# Altium schematic sheet 02-ALIM_2.SchDoc(02-ALIM_2)
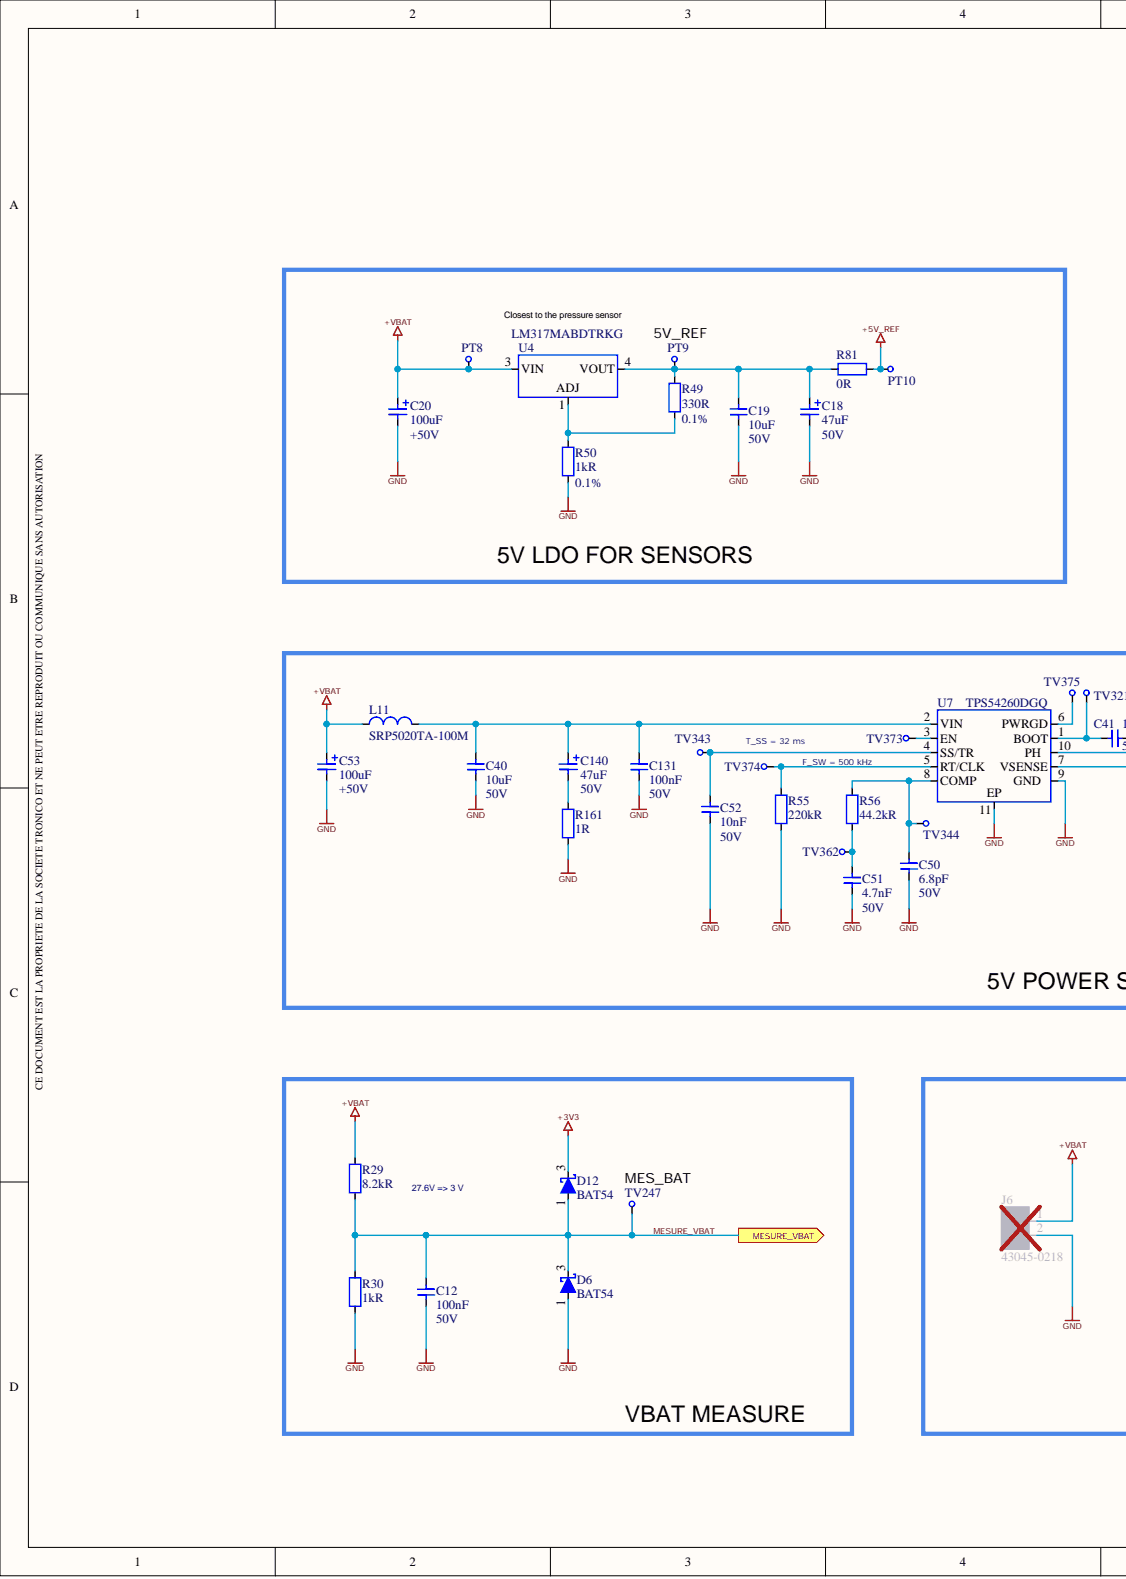
[diagram p0: 02-ALIM_2.SchDoc, left]
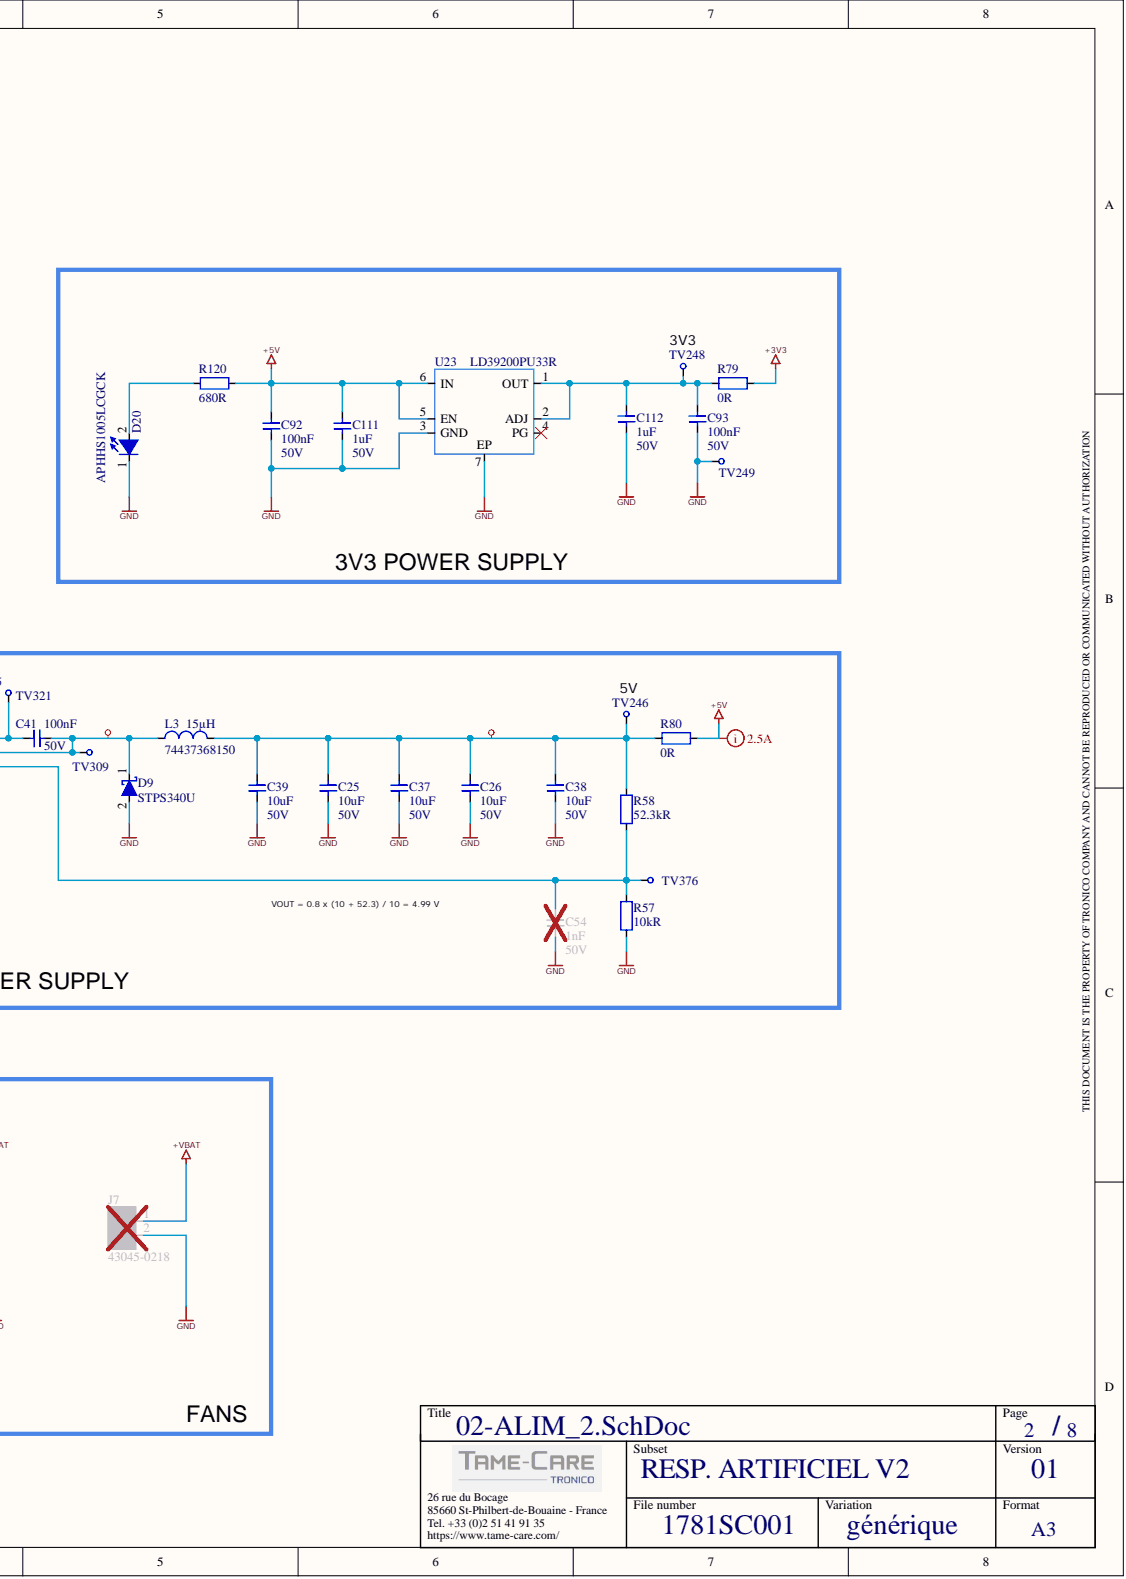
[diagram p1: 02-ALIM_2.SchDoc, right]

## Parts
C12  100nF  Parameter 1: 50V  [CAPC_0603X094_T]
C18  47uF  Parameter 1: 50V  [CAPE_D_B]
C19  10uF  Parameter 1: 50V  [CAPC_1206X160_T]
C20  100uF  Parameter 1: +50V  [CAPE_G_B]
C25  10uF  Parameter 1: 50V  [CAPC_1206X160_T]
C26  10uF  Parameter 1: 50V  [CAPC_1206X160_T]
C37  10uF  Parameter 1: 50V  [CAPC_1206X160_T]
C38  10uF  Parameter 1: 50V  [CAPC_1206X160_T]
C39  10uF  Parameter 1: 50V  [CAPC_1206X160_T]
C40  10uF  Parameter 1: 50V  [CAPC_1206X160_T]
C41  100nF  Parameter 1: 50V  [CAPC_0603X094_T]
C50  6.8pF  Parameter 1: 50V  [CAPC_0603X094_T]
C51  4.7nF  Parameter 1: 50V  [CAPC_0603X080_T]
C52  10nF  Parameter 1: 50V  [CAPC_0603X080_T]
C53  100uF  Parameter 1: +50V  [CAPE_G_B]
C54  1nF  Parameter 1: 50V  [CAPC_0603X080_T]
C92  100nF  Parameter 1: 50V  [CAPC_0603X094_T]
C93  100nF  Parameter 1: 50V  [CAPC_0603X094_T]
C111  1uF  Parameter 1: 50V  [CAPC_0603X080_T]
C112  1uF  Parameter 1: 50V  [CAPC_0603X080_T]
C131  100nF  Parameter 1: 50V  [CAPC_0603X094_T]
C140  47uF  Parameter 1: 50V  [CAPE_D_B]
D6  BAT54  [SOT23_T]
D9  STPS340U  [DIOC_SMB_DO-214AA_T]
D12  BAT54  [SOT23_T]
D20  APHHS1005LCGCK  [LEDC_0402x050_GREEN_B]
J6  43045-0218  [CNT_MOLEX_43045-0218_B]
J7  43045-0218  [CNT_MOLEX_43045-0218_B]
L3  74437368150  CHAMP5: 15µH  [INDC_WE-1040_B]
L11  SRP5020TA-100M  [INDC_SRP5020T_B]
PT8  PT_0.4  [PT_0.4-1.0mm]  (value not drawn)  (no BOM)
PT9  PT_0.4  [PT_0.4-1.0mm]  (value not drawn)  (no BOM)
PT10  PT_0.4  [PT_0.4-1.0mm]  (value not drawn)  (no BOM)
R29  8.2kR  [RESC_0603X045_T]
R30  1kR  [RESC_0603X045_T]
R49  330R  CHAMP7: 0.1%  [RESC_0603X045_T]
R50  1kR  CHAMP7: 0.1%  [RESC_0603X045_T]
R55  220kR  [RESC_0603X045_T]
R56  44.2kR  [RESC_0603X045_T]
R57  10kR  [RESC_0603X045_T]
R58  52.3kR  [RESC_0603X045_T]
R79  0R  [RESC_0603X045_T]
R80  0R  [RESC_1206X055_T]
R81  0R  [RESC_0603X045_T]
R120  680R  [RESC_0603X045_T]
R161  1R  [RESC_2512X060_T]
TV246  PT_0.4  [PT_0.4-1.0mm]  (value not drawn)  (no BOM)
TV247  PT_0.4  [PT_0.4-1.0mm]  (value not drawn)  (no BOM)
TV248  PT_0.4  [PT_0.4-1.0mm]  (value not drawn)  (no BOM)
TV249  PT_0.4  [PT_0.4-1.0mm]  (value not drawn)  (no BOM)
TV309  PT_0.4  [PT_0.4-1.0mm]  (value not drawn)  (no BOM)
TV321  PT_0.4  [PT_0.4-1.0mm]  (value not drawn)  (no BOM)
TV343  PT_0.4  [PT_0.4-1.0mm]  (value not drawn)  (no BOM)
TV344  PT_0.4  [PT_0.4-1.0mm]  (value not drawn)  (no BOM)
TV362  PT_0.4  [PT_0.4-1.0mm]  (value not drawn)  (no BOM)
TV373  PT_0.4  [PT_0.4-1.0mm]  (value not drawn)  (no BOM)
TV374  PT_0.4  [PT_0.4-1.0mm]  (value not drawn)  (no BOM)
TV375  PT_0.4  [PT_0.4-1.0mm]  (value not drawn)  (no BOM)
TV376  PT_0.4  [PT_0.4-1.0mm]  (value not drawn)  (no BOM)
U4  LM317MABDTRKG  [DPAK_TO252AA_B]
U7  TPS54260DGQ  [VSSOP10-EP_0.5_3.0X3.0X1.1_B]
U23  LD39200PU33R  [DFN6-EP_0.95_3.0X3.0X0.8_B]

## Ports
MESURE_VBAT

## Nets
+3V3: D12.3 R79.1
+5V: C92.2 C111.2 R80.1 R120.1 U23.5(EN) U23.6(IN)
+5V_REF: PT10.1 R81.1
+VBAT: C20.1 C53.1 J6.1 J7.1 L11.1 PT8.1 R29.1 U4.3
GND: C12.1 C18.2 C19.1 C20.2 C25.1 C26.1 C37.1 C38.1 C39.1 C40.1 C50.1 C51.1 C52.2 C53.2 C54.2 C92.1 C93.1 C111.1 C112.1 C131.1 D6.1 D9.2 D20.1 J6.2 J7.2 R30.2 R50.2 R55.2 R57.1 R161.2 TV249.1 U7.9(GND) U7.11(EP) U23.3(GND) U23.7(EP)
MESURE_VBAT: C12.2 D6.3 D12.1 R29.2 R30.1 TV247.1 ; port MESURE_VBAT
NetC18_1: C18.1 C19.2 PT9.1 R49.1 R81.2 U4.4
NetC25_2: C25.2 C26.2 C37.2 C38.2 C39.2 L3.2 R58.1 R80.2 TV246.1
NetC40_2: C40.2 C131.2 C140.1 L11.2 U7.2(VIN)
NetC41_1: C41.1 TV321.1 U7.1(BOOT)
NetC41_2: C41.2 D9.1 L3.1 TV309.1 U7.10(PH)
NetC50_2: C50.2 R56.1 TV344.1 U7.8(COMP)
NetC51_2: C51.2 R56.2 TV362.1
NetC52_1: C52.1 TV343.1 U7.4(SS/TR)
NetC54_1: C54.1 R57.2 R58.2 TV376.1 U7.7(VSENSE)
NetC93_2: C93.2 C112.2 R79.2 TV248.1 U23.1(OUT) U23.2(ADJ)
NetC140_2: C140.2 R161.1
NetD20_2: D20.2 R120.2
NetR49_2: R49.2 R50.1 U4.1
NetR55_1: R55.1 TV374.1 U7.5(RT/CLK)
NetTV373_1: TV373.1 U7.3(EN)
NetTV375_1: TV375.1 U7.6(PWRGD)

Not connected: U23 4(PG)

## Library data of the parts
C12 C41 C92 C93 C131 = 23U00036: COND_CERAM CMS 0603 X7R 100nF 50V 10%; REV_NOTE: Maj Champs Techniques; REV_AUTHOR: nlelievre; REF_FAB: 06035C104KAT2A; LEVEL: 1; FAMILLE: CAPACITIFS; FAB: AVX; Database Table Name: v_bt_all; CHAMP9: -55°C/+125°C; CHAMP2: 0603; SS_FAMILLE: COND_CERAM; Simulation: CAP; Signal Integrity: Cap; REV_DATE: 16/10/2019 13:20:57; Library Name: SVNDatabase_BaseTechnique.SVNDbLib; ID_RnD: 23U00036; HelpURL: http://Bt_rnd/ds/02_capacitif/AVX/AVX_cx7r.pdf; ComponentLink2Description: Manufacturer Website; ComponentLink1Description: Manufacturer Datasheet; Component Kind: Standard; CHAMP7: 10%; CHAMP6: 50V; CHAMP5: 100nF; CHAMP3: X7R; CHAMP1: CMS; ACTIF: ACTIF
C18 C140 = 21U00041: COND_ALUM CMS D:6.3mm 47uF 50V 20% 680mR; REV_NOTE: Migration vers t_bt; REV_AUTHOR: lclavier; REF_FAB: EEEFT1H470AP; QUALIF: None; LEVEL: 1; FAMILLE: CAPACITIFS; FAB: PANASONIC; Database Table Name: v_bt_all; CHAMP9: -55°C/+105°C; SS_FAMILLE: COND_ALUM; Simulation: CAP; Signal Integrity: Cap Pol; REV_DATE: 14/04/2020 16:15:27; ID_RnD: 21U00041; HelpURL: http://bt_rnd/ds/02_capacitif/Panasonic/EEEFT1H470AP.pdf; ComponentLink1URL: https://industrial.panasonic.com/ww/products/capacitors/aluminum-capacitors/aluminum-cap-smd/ft-v-high-temp-reflow/EEEFT1H470AP; Component Kind: Standard; CHAMP8: 680mR; CHAMP7: 20%; CHAMP6: 50V; CHAMP5: 47uF; CHAMP3: D:6.3mm; CHAMP1: CMS; ACTIF: ACTIF; Library Name: SVNDatabase_BaseTechnique.SVNDbLib
C19 C25 C26 C37-C40 = 23U00488: COND_CERAM CMS 1206 X5R 10uF 50V 10%; REV_NOTE: Migration vers t_bt; REV_AUTHOR: lclavier; REF_FAB: GRM31CR61H106KA12L; LEVEL: 1; FAMILLE: CAPACITIFS; FAB: MURATA; Database Table Name: v_bt_all; CHAMP9: -55°C/+85°C; CHAMP2: 1206; SS_FAMILLE: COND_CERAM; Simulation: CAP; Signal Integrity: Cap; REV_DATE: 14/04/2020 16:23:14; ID_RnD: 23U00488; HelpURL: http://bt_rnd/ds/02_capacitif/murata/GRM31CR61H106KA12L.pdf; ComponentLink1URL: https://www.murata.com/en-us/products/productdetail?partno=GRM31CR61H106KA12%23; Component Kind: Standard; CHAMP7: 10%; CHAMP6: 50V; CHAMP5: 10uF; CHAMP3: X5R; CHAMP1: CMS; ACTIF: ACTIF; Library Name: SVNDatabase_BaseTechnique.SVNDbLib
C20 C53 = 21U00015: COND_ALUM CMS D:10mm 100uF +50V 20% 28mR; REV_NOTE: MaJ Champs Techniques; REV_AUTHOR: lclavier; REF_FAB: EEHZA1H101P; LEVEL: 1; FAMILLE: CAPACITIFS; FAB: PANASONIC; Database Table Name: v_bt_all; CHAMP9: -55°C/+105°C; SS_FAMILLE: COND_ALUM; Simulation: CAP; Signal Integrity: Cap Pol; REV_DATE: 11/02/2020 09:58:52; Library Name: SVNDatabase_BaseTechnique.SVNDbLib; ID_RnD: 21U00015; HelpURL: http://bt_rnd/ds/02_capacitif/Panasonic/PANASONIC_ABA0000CE136.pdf; ComponentLink2Description: Manufacturer Website; ComponentLink1Description: https://industrial.panasonic.com/cdbs/www-data/pdf/RDE0000/DME0000COL92.pdf; Component Kind: Standard; CHAMP8: 28mR; CHAMP7: 20%; CHAMP6: +50V; CHAMP5: 100uF; CHAMP3: D:10mm; CHAMP1: CMS; ACTIF: ACTIF
C50 = 23U00055: COND_CERAM CMS 0603 C0G 6.8pF 50V 0.25pF; REV_NOTE: Maj Champs Techniques; REV_AUTHOR: nlelievre; REF_FAB: C0603C689C5GACTU; LEVEL: 1; FAMILLE: CAPACITIFS; FAB: KEMET; Database Table Name: v_bt_all; CHAMP9: -55°C/+125°C; CHAMP2: 0603; SS_FAMILLE: COND_CERAM; Simulation: CAP; Signal Integrity: Cap; REV_DATE: 16/10/2019 13:26:48; Library Name: SVNDatabase_BaseTechnique.SVNDbLib; ID_RnD: 23U00055; HelpURL: http://bt_rnd/ds/02_capacitif/KEMET/KEMET_KEM_C1003_C0G_SMD.pdf; ComponentLink2Description: None; ComponentLink1Description: Manufacturer Datasheet; Component Kind: Standard; CHAMP7: 0.25pF; CHAMP6: 50V; CHAMP5: 6.8pF; CHAMP3: C0G; CHAMP1: CMS; ACTIF: ACTIF
C51 = 23U00490: COND_CERAM CMS 0603 X7R 4.7nF 50V 5%; REV_NOTE: Migration vers t_bt; REV_AUTHOR: lclavier; REF_FAB: C0603C472J5RACTU; LEVEL: 1; FAMILLE: CAPACITIFS; FAB: KEMET; Database Table Name: v_bt_all; CHAMP9: -55°C/+125°C; CHAMP2: 0603; SS_FAMILLE: COND_CERAM; Simulation: CAP; Signal Integrity: Cap; REV_DATE: 20/04/2020 18:40:48; ID_RnD: 23U00490; HelpURL: http://BT_RND/ds/02_capacitif/KEMET/KEMET_KEM_C1002_X7R_SMD.pdf; ComponentLink2Description: Manufacturer Website; ComponentLink1Description: Manufacturer Datasheet; Component Kind: Standard; CHAMP7: 5%; CHAMP6: 50V; CHAMP5: 4.7nF; CHAMP3: X7R; CHAMP1: CMS; ACTIF: ACTIF; Library Name: SVNDatabase_BaseTechnique.SVNDbLib
C52 = 23U00104: COND_CERAM CMS 0603 X7R 10nF 50V 10%; REV_NOTE: Maj Champs Techniques; REV_AUTHOR: nlelievre; REF_FAB: C0603C103K5RACTU; LEVEL: 1; FAMILLE: CAPACITIFS; FAB: KEMET; Database Table Name: v_bt_all; CHAMP9: -55°C/+125°C; CHAMP2: 0603; SS_FAMILLE: COND_CERAM; Simulation: CAP; Signal Integrity: Cap; REV_DATE: 16/10/2019 13:28:44; Library Name: SVNDatabase_BaseTechnique.SVNDbLib; ID_RnD: 23U00104; HelpURL: http://bt_rnd/ds/02_capacitif/KEMET/KEMET_KEM_C1002_X7R_SMD.pdf; ComponentLink2Description: Manufacturer Website; ComponentLink1Description: Manufacturer Datasheet; Component Kind: Standard; CHAMP7: 10%; CHAMP6: 50V; CHAMP5: 10nF; CHAMP3: X7R; CHAMP1: CMS; ACTIF: ACTIF
C54 = 23U00183: COND_CERAM CMS 0603 X7R 1nF 50V 10%; REV_NOTE: Maj Champs Techniques; REV_AUTHOR: nlelievre; REF_FAB: GRM188R71H102KA01D; LEVEL: 1; FAMILLE: CAPACITIFS; FAB: MURATA; Database Table Name: v_bt_all; CHAMP9: -55°C/+125°C; CHAMP2: 0603; SS_FAMILLE: COND_CERAM; Simulation: CAP; Signal Integrity: Cap; REV_DATE: 16/10/2019 13:30:44; Library Name: SVNDatabase_BaseTechnique.SVNDbLib; ID_RnD: 23U00183; HelpURL: http://bt_rnd/ds/02_capacitif/murata/murata_c02e.pdf; ComponentLink2Description: Manufacturer Website; ComponentLink1URL: http://www.murata.com/~/media/webrenewal/support/library/catalog/products/capacitor/mlcc/c02e.pdf; ComponentLink1Description: Manufacturer Datasheet; Component Kind: Standard; CHAMP7: 10%; CHAMP6: 50V; CHAMP5: 1nF; CHAMP3: X7R; CHAMP1: CMS; ACTIF: ACTIF
C111 C112 = 23U00347: COND_CERAM CMS 0603 X5R 1uF 50V 10%; REV_NOTE: Maj Champs Techniques; REV_AUTHOR: nlelievre; REF_FAB: CGA3E3X5R1H105K080AB; LEVEL: 1; FAMILLE: CAPACITIFS; FAB: TDK; Database Table Name: v_bt_all; CHAMP9: -55°C/+85°C; CHAMP2: 0603; SS_FAMILLE: COND_CERAM; Simulation: CAP; Signal Integrity: Cap; REV_DATE: 16/10/2019 13:35:17; Library Name: SVNDatabase_BaseTechnique.SVNDbLib; ID_RnD: 23U00347; HelpURL: http://bt_rnd/ds/02_capacitif/TDK/mlccspec_automotive_general_midvoltage_en.pdf; ComponentLink2Description: Manufacturer Website; ComponentLink1Description: Manufacturer Datasheet; Component Kind: Standard; CHAMP7: 10%; CHAMP6: 50V; CHAMP5: 1uF; CHAMP3: X5R; CHAMP1: CMS; ACTIF: ACTIF
D6 D12 = 33U00013: DIODE_DE_SIGNAL CMS SOT23  30V 200mA -55°C/+150°C -55°C/+150°C; REV_NOTE: MàJ footprint_path et footprint_ref; REV_AUTHOR: llannuzel; QUALIF: None; LEVEL: 1; FAMILLE: DIODES; FAB: FAIRCHILD; Database Table Name: v_bt_all; SS_FAMILLE: DIODE_DE_SIGNAL; Simulation: SKYDIODE; Signal Integrity: D Schottky; REV_DATE: 13/12/2019 10:03:18; REF_FAB: BAT54; ID_RnD: 33U00013; HelpURL: http://bt_rnd/ds/03_diode/FAIRCHILD/BAT54_x.pdf; ComponentLink2Description: Manufacturer Website; ComponentLink1Description: Manufacturer Datasheet; Component Kind: Standard; CHAMP10: -55°C/+150°C; CHAMP9: -55°C/+150°C; CHAMP6: 200mA; CHAMP5: 30V; CHAMP2: SOT23; CHAMP1: CMS; ACTIF: ACTIF; Library Name: SVNDatabase_BaseTechnique.SVNDbLib
D9 = 39U00073: DIODE_SCHOTTKY CMS SMB 40V 3A SINGLE; REV_NOTE: Migration vers t_bt; REV_AUTHOR: lclavier; LEVEL: 1; FAMILLE: DIODES; FAB: ST MICROELECTRONICS; Database Table Name: v_bt_all; CHAMP10: -65°C/+150°C; CHAMP9: -40°C/+105°C; SS_FAMILLE: DIODE_SCHOTTKY; Simulation: SKYDIODE; Signal Integrity: D Schottky; REV_DATE: 17/04/2020 20:16:17; REF_FAB: STPS340U; ID_RnD: 39U00073; HelpURL: http://bt_rnd/ds/03_diode/ST/stps340.pdf; ComponentLink2Description: Manufacturer Website; ComponentLink1Description: Manufacturer Datasheet; Component Kind: Standard; CHAMP8: SINGLE; CHAMP6: 3A; CHAMP5: 40V; CHAMP2: SMB; CHAMP1: CMS; ACTIF: ACTIF; Library Name: SVNDatabase_BaseTechnique.SVNDbLib
D20 = E1U00022: DIODE_LED VERTE CMS 0402 574nm 3.5mcd 1.9V; REV_NOTE: MaJ Champs URL; REV_AUTHOR: lclavier; QUALIF: None; LEVEL: 1; FAMILLE: OPTOELECTRONIQUE; FAB: KINGBRIGHT; Database Table Name: v_bt_all; CHAMP10: -40°C/+85°C; CHAMP8: 2mA; CHAMP4: 0402; [ Valide ]: OK; SS_FAMILLE: DIODE_LED; REV_DATE: 15/02/2019 16:02:32; REF_FAB: APHHS1005LCGCK; ID_RnD: E1U00022; HelpURL: http://bt_rnd/ds/15_opto/kingbright/APHHS1005LCGCK.pdf; ComponentLink2Description: Manufacturer Website; ComponentLink1Description: Manufacturer Datasheet; Component Kind: Standard; CHAMP9: -40°C/+85°C; CHAMP7: 1.9V; CHAMP6: 3.5mcd; CHAMP5: 574nm; CHAMP3: CMS; CHAMP1: VERTE; ACTIF: ACTIF; Library Name: SVNDatabase_BaseTechnique.SVNDbLib
J6 J7 = A7U00324: CONNECT MICRO-FIT CMS 2PTS EMBASE RECT MALE DROIT; Valide: OK; REV_NOTE: Migration vers t_bt; REV_AUTHOR: lclavier; LEVEL: 1; FAMILLE: CONNECTIQUE; FAB: MOLEX; Database Table Name: v_bt_all; CHAMP10: -40°C/+105°C; SS_FAMILLE: CONNECT; REV_DATE: 04/05/2020 18:19:25; REF_FAB: 43045-0218; ID_RnD: A7U00324; HelpURL: http://bt_rnd/ds/10_connectique/MOLEX/430450218_sd.pdf; ComponentLink2Description: Manufacturer Website; ComponentLink1Description: Manufacturer Datasheet; Component Kind: Standard; CHAMP9: -40°C/+105°C; CHAMP8: DROIT; CHAMP7: MALE; CHAMP6: RECT; CHAMP5: EMBASE; CHAMP4: 2PTS; CHAMP3: CMS; CHAMP1: MICRO-FIT; ACTIF: ACTIF; Library Name: SVNDatabase_BaseTechnique.SVNDbLib
L3 = 42U00158: SELF CMS 1040 15µH 20% 8.5A; REV_NOTE: Migration vers t_bt; REV_AUTHOR: lclavier; LEVEL: 1; FAMILLE: INDUCTIFS; FAB: WURTH ELEKTRONIK; Database Table Name: v_bt_all; CHAMP9: -40°C/+125°C; CHAMP2: 1040; SS_FAMILLE: SELF; Simulation: INDUCTOR; Signal Integrity: Inductor; REV_DATE: 20/04/2020 18:11:54; REF_FAB: 74437368150; ID_RnD: 42U00158; HelpURL: http://bt_rnd/ds/04_inductif/WE/74437368150.pdf; ComponentLink2Description: Manufacturer Website; ComponentLink1Description: Manufacturer Datasheet; Component Kind: Standard; CHAMP7: 8.5A; CHAMP6: 20%; CHAMP1: CMS; ACTIF: ACTIF; Library Name: SVNDatabase_BaseTechnique.SVNDbLib
L11 = 42U00140: SELF CMS 5.2x5.7x1.8mm 10µH 20% 3.4A; REV_NOTE: MaJ Champs Librairies; REV_AUTHOR: lclavier; LEVEL: 1; FAMILLE: INDUCTIFS; FAB: BOURNS; Database Table Name: v_bt_all; CHAMP10: -40°C/+150°C; SS_FAMILLE: SELF; Simulation: INDUCTOR; Signal Integrity: Inductor; REV_DATE: 21/10/2019 17:00:03; REF_FAB: SRP5020TA-100M; ID_RnD: 42U00140; HelpURL: http://bt_rnd/ds/04_inductif/BOURNS/srp5020ta.pdf; ComponentLink2Description: Manufacturer Website; ComponentLink1Description: Manufacturer Datasheet; Component Kind: Standard; CHAMP9: -40°C/+150°C; CHAMP7: 3.4A; CHAMP6: 20%; CHAMP5: 10µH; CHAMP2: 5.2x5.7x1.8mm; CHAMP1: CMS; ACTIF: ACTIF; Library Name: SVNDatabase_BaseTechnique.SVNDbLib
PT8-PT10 TV246-TV249 TV309 TV321 TV343 TV344 TV362 TV373-TV376 = H6U00006: SS_FAMILLE: POINT_TEST; REV_NOTE: Migration vers t_bt; REV_AUTHOR: llannuzel; LEVEL: 1; FAMILLE: DIVERS; FAB: TRONICO; Database Table Name: v_bt_all; CHAMP1: PASTILLE; REV_DATE: 01/05/2020 18:33:20; REF_FAB: PT_0.4; ID_RnD: H6U00006; HelpURL: http://bt_rnd/ds/; ComponentLink2Description: Manufacturer Website; ComponentLink1Description: Manufacturer Datasheet; ACTIF: ACTIF; Library Name: SVNDatabase_BaseTechnique.SVNDbLib
R29 = 11U00585: REST CMS 0603 100PPM 8.2kR 1/10W 1% -55°C/+155°C; Valide / Non Valide: OK; REV_NOTE: MaJ description; REV_AUTHOR: jrenvoise; REF_FAB: RC0603FR-078K2L; LEVEL: 1; FAMILLE: RESISTIFS; FAB: YAGEO; Database Table Name: v_bt_all; CHAMP2: 0603; SS_FAMILLE: REST; Simulation: RESISTOR; Signal Integrity: Res; REV_DATE: 12/09/2018 11:17:00; ID_RnD: 11U00585; HelpURL: http://bt_rnd/ds/01_resistif/yageo/RC-L_Series.pdf; ComponentLink2Description: Manufacturer Website; ComponentLink1Description: Manufacturer Datasheet; Component Kind: Standard; CHAMP9: -55°C/+155°C; CHAMP7: 1%; CHAMP6: 1/10W; CHAMP5: 8.2kR; CHAMP3: 100PPM; CHAMP1: CMS; ACTIF: ACTIF; Library Name: SVNDatabase_BaseTechnique.SVNDbLib
R30 = 11U00110: REST CMS 0603 100PPM 1kR 1/10W 1% -55°C/+155°C; Valide / Non Valide: OK; REV_NOTE: MaJ description; REV_AUTHOR: jrenvoise; REF_FAB: RC0603FR-071KL; LEVEL: 1; FAMILLE: RESISTIFS; FAB: YAGEO; Database Table Name: v_bt_all; CHAMP2: 0603; SS_FAMILLE: REST; Simulation: RESISTOR; Signal Integrity: Res; REV_DATE: 12/09/2018 10:54:26; ID_RnD: 11U00110; HelpURL: http://bt_rnd/ds/01_resistif/yageo/RC-L_Series.pdf; ComponentLink2Description: Manufacturer Website; ComponentLink1Description: Manufacturer Datasheet; Component Kind: Standard; CHAMP9: -55°C/+155°C; CHAMP7: 1%; CHAMP6: 1/10W; CHAMP5: 1kR; CHAMP3: 100PPM; CHAMP1: CMS; ACTIF: ACTIF; Library Name: SVNDatabase_BaseTechnique.SVNDbLib
R49 = 11U01020: REST CMS 0603 25PPM 330R 1/10W 0.1% 75V; Valide / Non Valide: OK; REV_NOTE: Migration vers t_bt; REV_AUTHOR: llannuzel; REF_FAB: ERA-3AEB331V; LEVEL: 1; FAMILLE: RESISTIFS; FAB: PANASONIC; Database Table Name: v_bt_all; CHAMP9: -55°C/+85°C; CHAMP2: 0603; SS_FAMILLE: REST; Simulation: RESISTOR; Signal Integrity: Res; REV_DATE: 02/05/2020 15:10:09; ID_RnD: 11U01020; HelpURL: http://bt_rnd/ds/01_resistif/Panasonic/AOA0000C307.pdf; Component Kind: Standard; CHAMP8: 75V; CHAMP6: 1/10W; CHAMP5: 330R; CHAMP3: 25PPM; CHAMP1: CMS; ACTIF: ACTIF; Library Name: SVNDatabase_BaseTechnique.SVNDbLib
R50 = 11U00834: REST CMS 0603 10PPM 1kR 1/8W 0.1% 75V; Valide / Non Valide: OK; REV_NOTE: Migration vers t_bt; REV_AUTHOR: lclavier; REF_FAB: TNPW06031K00BYEN; LEVEL: 1; FAMILLE: RESISTIFS; FAB: VISHAY; Database Table Name: v_bt_all; CHAMP9: -55°C/+155°C; CHAMP2: 0603; SS_FAMILLE: REST; Simulation: RESISTOR; Signal Integrity: Res; REV_DATE: 17/10/2019 13:46:40; ID_RnD: 11U00834; HelpURL: http://bt_rnd/ds/01_resistif/Vishay/tnpw_e3.pdf; ComponentLink2Description: Manufacturer Website; ComponentLink1Description: Manufacturer Datasheet; Component Kind: Standard; CHAMP8: 75V; CHAMP6: 1/8W; CHAMP5: 1kR; CHAMP3: 10PPM; CHAMP1: CMS; ACTIF: ACTIF; Library Name: SVNDatabase_BaseTechnique.SVNDbLib
R55 = 11U01019: REST CMS 0603 100PPM 220kR 1/10W 1% 75V; Valide / Non Valide: OK; REV_NOTE: Migration vers t_bt; REV_AUTHOR: lclavier; REF_FAB: RC0603FR-07220KL; LEVEL: 1; FAMILLE: RESISTIFS; FAB: YAGEO; Database Table Name: v_bt_all; CHAMP9: -55°C/+155°C; CHAMP2: 0603; SS_FAMILLE: REST; Simulation: RESISTOR; Signal Integrity: Res; REV_DATE: 21/04/2020 09:23:29; ID_RnD: 11U01019; HelpURL: http://bt_rnd/ds/01_resistif/yageo/RC-L_Series.pdf; ComponentLink2Description: Manufacturer Website; ComponentLink1Description: Manufacturer Datasheet; Component Kind: Standard; CHAMP8: 75V; CHAMP7: 1%; CHAMP6: 1/10W; CHAMP5: 220kR; CHAMP3: 100PPM; CHAMP1: CMS; ACTIF: ACTIF; Library Name: SVNDatabase_BaseTechnique.SVNDbLib
R56 = 11U01013: REST CMS 0603 100PPM 44.2kR 1/10W 1% 75V; Valide / Non Valide: OK; REV_NOTE: MaJ Champs Techniques; REV_AUTHOR: jcaille; REF_FAB: CRCW060344K2FKEA; LEVEL: 1; FAMILLE: RESISTIFS; FAB: VISHAY; Database Table Name: v_bt_all; CHAMP9: -55°C/+155°C; CHAMP2: 0603; SS_FAMILLE: REST; Simulation: RESISTOR; Signal Integrity: Res; REV_DATE: 17/04/2020 12:14:56; Library Name: SVNDatabase_BaseTechnique.SVNDbLib; ID_RnD: 11U01013; HelpURL: http://bt_rnd/ds/01_resistif/Vishay/dcrcwe3.pdf; ComponentLink2Description: Manufacturer Website; ComponentLink1Description: Manufacturer Datasheet; Component Kind: Standard; CHAMP8: 75V; CHAMP7: 1%; CHAMP6: 1/10W; CHAMP5: 44.2kR; CHAMP3: 100PPM; CHAMP1: CMS; ACTIF: ACTIF
R57 = 11U00108: REST CMS 0603 100PPM 10kR 1/10W 1% -55°C/+155°C; Valide / Non Valide: OK; REV_NOTE: MaJ description; REV_AUTHOR: jrenvoise; REF_FAB: RC0603FR-0710KL; LEVEL: 1; FAMILLE: RESISTIFS; FAB: YAGEO; Database Table Name: v_bt_all; CHAMP2: 0603; SS_FAMILLE: REST; Simulation: RESISTOR; Signal Integrity: Res; REV_DATE: 12/09/2018 10:54:26; ID_RnD: 11U00108; HelpURL: http://bt_rnd/ds/01_resistif/yageo/RC-L_Series.pdf; ComponentLink2Description: Manufacturer Website; ComponentLink1Description: Manufacturer Datasheet; Component Kind: Standard; CHAMP9: -55°C/+155°C; CHAMP7: 1%; CHAMP6: 1/10W; CHAMP5: 10kR; CHAMP3: 100PPM; CHAMP1: CMS; ACTIF: ACTIF; Library Name: SVNDatabase_BaseTechnique.SVNDbLib
R58 = 11U01017: REST CMS 0603 100PPM 52.3kR 1/10W 1% 75V; Valide / Non Valide: OK; REV_NOTE: Migration vers t_bt; REV_AUTHOR: lclavier; REF_FAB: RC0603FR-0752K3L; LEVEL: 1; FAMILLE: RESISTIFS; FAB: YAGEO; Database Table Name: v_bt_all; CHAMP9: -55°C/+155°C; CHAMP2: 0603; SS_FAMILLE: REST; Simulation: RESISTOR; Signal Integrity: Res; REV_DATE: 20/04/2020 18:36:47; ID_RnD: 11U01017; HelpURL: http://bt_rnd/ds/01_resistif/yageo/RC-L_Series.pdf; ComponentLink2Description: Manufacturer Website; ComponentLink1Description: Manufacturer Datasheet; Component Kind: Standard; CHAMP8: 75V; CHAMP7: 1%; CHAMP6: 1/10W; CHAMP5: 52.3kR; CHAMP3: 100PPM; CHAMP1: CMS; ACTIF: ACTIF; Library Name: SVNDatabase_BaseTechnique.SVNDbLib
R79 R81 = 11U00009: REST CMS 0603 100PPM  0R 1/10W 20mR -55°C/+155°C; Valide / Non Valide: OK; REV_NOTE: MaJ Champs URL; REV_AUTHOR: jrenvoise; REF_FAB: CRCW06030000Z0EA; LEVEL: 1; FAMILLE: RESISTIFS; FAB: VISHAY; Database Table Name: v_bt_all; CHAMP2: 0603; SS_FAMILLE: REST; Simulation: RESISTOR; Signal Integrity: Res; REV_DATE: 24/10/2018 10:43:14; ID_RnD: 11U00009; HelpURL: http://bt_rnd/ds/01_resistif/vishay/Vishay_D-CRCWE3.pdf; ComponentLink2Description: Manufacturer Website; ComponentLink1URL: http://www.vishay.com/docs/20035/dcrcwe3.pdf; ComponentLink1Description: Manufacturer Datasheet; Component Kind: Standard; CHAMP9: -55°C/+155°C; CHAMP8: 20mR; CHAMP6: 1/10W; CHAMP5: 0R; CHAMP3: 100PPM; CHAMP1: CMS; ACTIF: ACTIF; Library Name: SVNDatabase_BaseTechnique.SVNDbLib
R80 = 11U00878: REST CMS 1206 0R 1/4W 20mR 3.5A; Valide / Non Valide: OK; REV_NOTE: Migration vers t_bt; REV_AUTHOR: jcaille; REF_FAB: RCA12060000ZSEA; LEVEL: 1; FAMILLE: RESISTIFS; FAB: VISHAY; Database Table Name: v_bt_all; CHAMP9: -55°C/+155°C; CHAMP2: 1206; SS_FAMILLE: REST; Simulation: RESISTOR; Signal Integrity: Res; REV_DATE: 25/11/2019 10:49:44; ID_RnD: 11U00878; HelpURL: http://bt_rnd/ds/01_resistif/Vishay/RCAE3.pdf; ComponentLink2Description: Manufacturer Website; ComponentLink1Description: Manufacturer Datasheet; Component Kind: Standard; CHAMP8: 3.5A; CHAMP7: 20mR; CHAMP6: 1/4W; CHAMP5: 0R; CHAMP1: CMS; ACTIF: ACTIF; Library Name: SVNDatabase_BaseTechnique.SVNDbLib
R120 = 11U00072: REST CMS 0603 100PPM  680R 1/10W 1% -55°C/+155°C; Valide / Non Valide: OK; REV_NOTE: MaJ description; REV_AUTHOR: jrenvoise; REF_FAB: RC0603FR-07680RL; LEVEL: 1; FAMILLE: RESISTIFS; FAB: YAGEO; Database Table Name: v_bt_all; CHAMP2: 0603; SS_FAMILLE: REST; Simulation: RESISTOR; Signal Integrity: Res; REV_DATE: 12/09/2018 11:17:00; ID_RnD: 11U00072; HelpURL: http://bt_rnd/ds/01_resistif/YAGEO/RC-L_Series.pdf; ComponentLink2Description: Manufacturer Website; ComponentLink1Description: Manufacturer Datasheet; Component Kind: Standard; CHAMP9: -55°C/+155°C; CHAMP7: 1%; CHAMP6: 1/10W; CHAMP5: 680R; CHAMP3: 100PPM; CHAMP1: CMS; ACTIF: ACTIF; Library Name: SVNDatabase_BaseTechnique.SVNDbLib
R161 = 11U01009: REST CMS 2512 100PPM 1R 1.5W 1% 500V; Valide / Non Valide: OK; REV_NOTE: MaJ Champs Techniques; REV_AUTHOR: llannuzel; REF_FAB: CRCW25121R00FKEGHP; QUALIF: None; LEVEL: 1; FAMILLE: RESISTIFS; FAB: VISHAY; Database Table Name: v_bt_all; CHAMP9: -55°C/+155°C; CHAMP2: 2512; SS_FAMILLE: REST; Simulation: RESISTOR; Signal Integrity: Res; REV_DATE: 16/04/2020 13:00:07; Library Name: SVNDatabase_BaseTechnique.SVNDbLib; ID_RnD: 11U01009; HelpURL: file://bt_rnd/ds/01_resistif/Vishay/CRCW25121R00FKEGHP.pdf; ComponentLink1URL: https://octopart.com/crcw25121r00fkeghp-vishay-44382594?r=sp; Component Kind: Standard; CHAMP8: 500V; CHAMP7: 1%; CHAMP6: 1.5W; CHAMP5: 1R; CHAMP3: 100PPM; CHAMP1: CMS; ACTIF: ACTIF
U4 = 62U00134: CI_REGUL CMS DPAK LIN +3V/+40V +1.2V/+37V LDO; Valide: OK; REV_NOTE: Migration vers t_bt; REV_AUTHOR: lclavier; LEVEL: 1; FAMILLE: CIRCUITS_INTEGRES; FAB: ON SEMICONDUCTOR; Database Table Name: v_bt_all; CHAMP10: -65°C/+150°C; CHAMP9: -40°C/+125°C; SS_FAMILLE: CI_REGUL; REV_DATE: 02/05/2020 13:21:32; REF_FAB: LM317MABDTRKG; ID_RnD: 62U00134; HelpURL: http://bt_rnd/ds/06_ci/ON/LM317M-D.PDF; ComponentLink2Description: Manufacturer Website; ComponentLink1Description: Manufacturer Datasheet; Component Kind: Standard; CHAMP8: LDO; CHAMP7: +1.2V/+37V; CHAMP6: +3V/+40V; CHAMP5: LIN; CHAMP2: DPAK; CHAMP1: CMS; ACTIF: ACTIF; Library Name: SVNDatabase_BaseTechnique.SVNDbLib
U7 = 62U00131: CI_REGUL CMS VSSOP10 DEC +3.5V/+60V; +8V/+65V; REV_NOTE: Migration vers t_bt; REV_AUTHOR: lclavier; LEVEL: 1; FAMILLE: CIRCUITS_INTEGRES; FAB: TEXAS INSTRUMENTS; Database Table Name: v_bt_all; CHAMP9: -40°C/+125°C; SS_FAMILLE: CI_REGUL; REV_DATE: 20/04/2020 18:11:59; REF_FAB: TPS54260DGQ; ID_RnD: 62U00131; HelpURL: http://bt_rnd/ds/06_ci/TI/tps54260.pdf; ComponentLink2Description: Manufacturer Website; ComponentLink1Description: Manufacturer Datasheet; Component Kind: Standard; CHAMP6: +3.5V/+60V; +8V/+65V; CHAMP5: DEC; CHAMP2: VSSOP10; CHAMP1: CMS; ACTIF: ACTIF; Library Name: SVNDatabase_BaseTechnique.SVNDbLib
U23 = 62U00132: CI_REGUL CMS DFN6 LIN +1.25V/+6V +3.3V/2A LDO; REV_NOTE: Migration vers t_bt; REV_AUTHOR: lclavier; LEVEL: 1; FAMILLE: CIRCUITS_INTEGRES; FAB: ST MICROELECTRONICS; Database Table Name: v_bt_all; CHAMP10: -55°C/+150°C; CHAMP9: -40°C/+125°C; SS_FAMILLE: CI_REGUL; REV_DATE: 29/04/2020 18:52:00; REF_FAB: LD39200PU33R; ID_RnD: 62U00132; HelpURL: http://bt_rnd/ds/06_ci/ST/ld39200.pdf; ComponentLink2Description: Manufacturer Website; ComponentLink1Description: Manufacturer Datasheet; Component Kind: Standard; CHAMP8: LDO; CHAMP7: +3.3V/2A; CHAMP6: +1.25V/+6V; CHAMP5: LIN; CHAMP2: DFN6; CHAMP1: CMS; ACTIF: ACTIF; Library Name: SVNDatabase_BaseTechnique.SVNDbLib
